# Altium schematic sheet Fasma_Drum_final.SchDoc
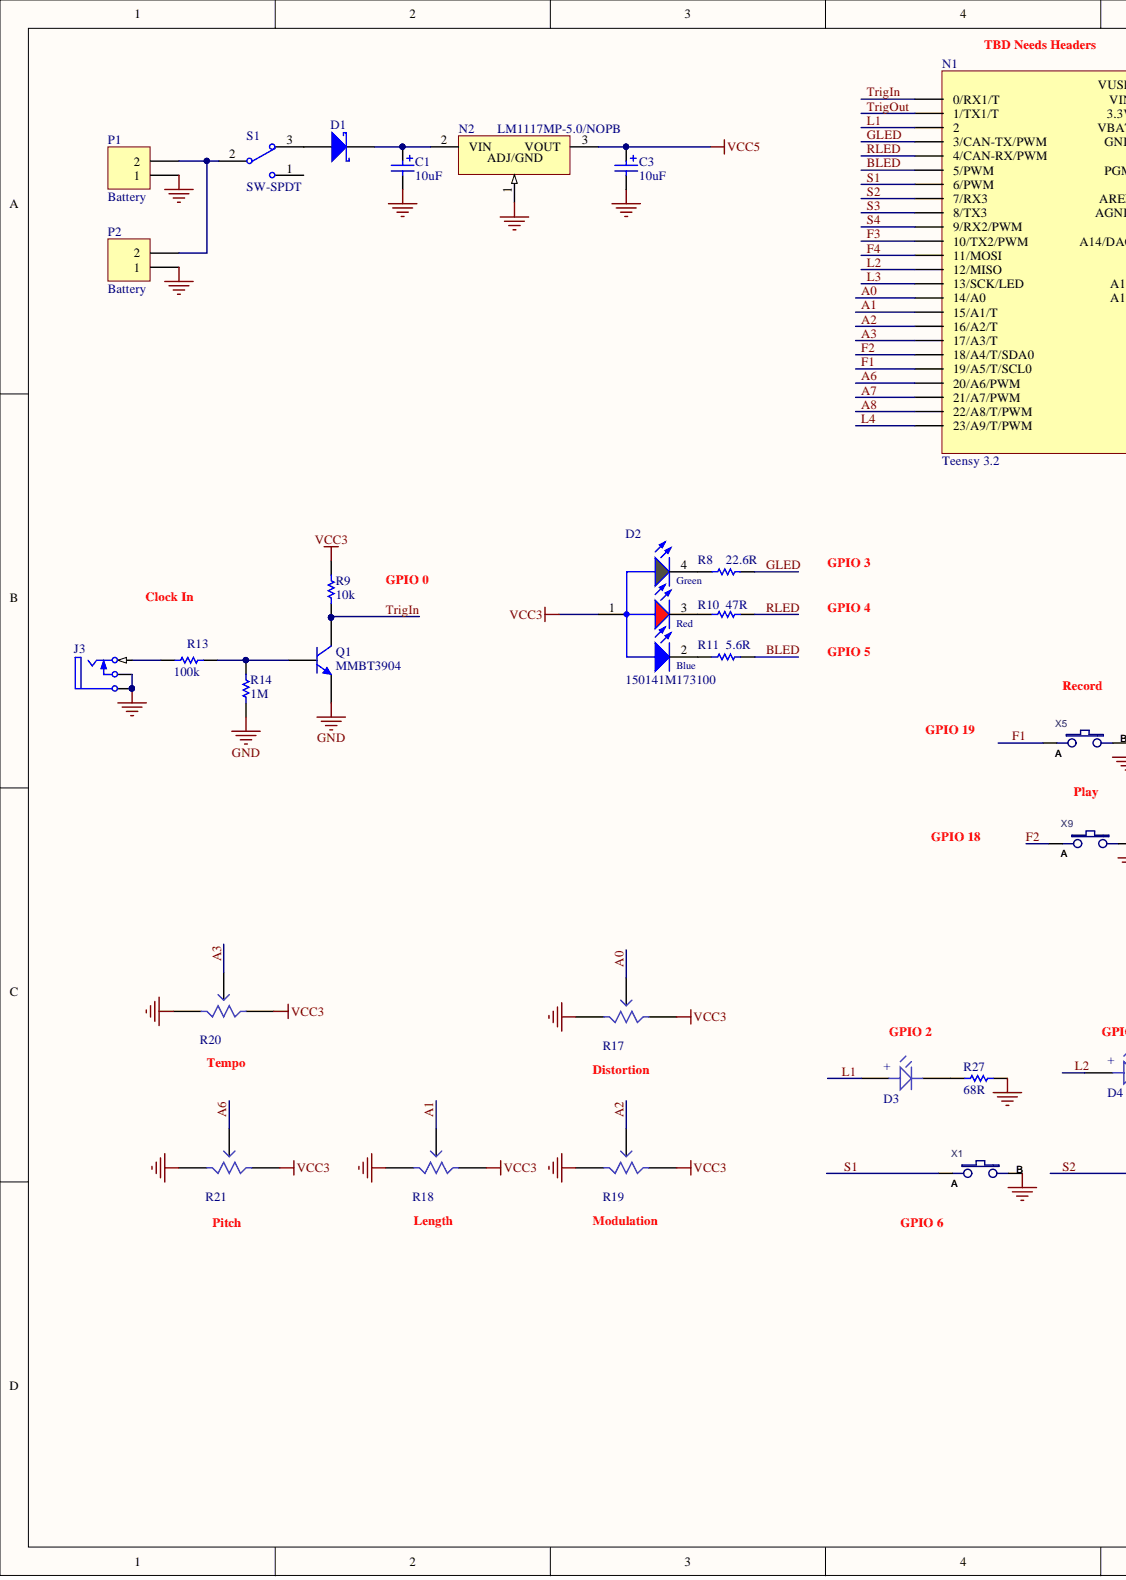
[diagram p0: Fasma_Drum_final.SchDoc, left]
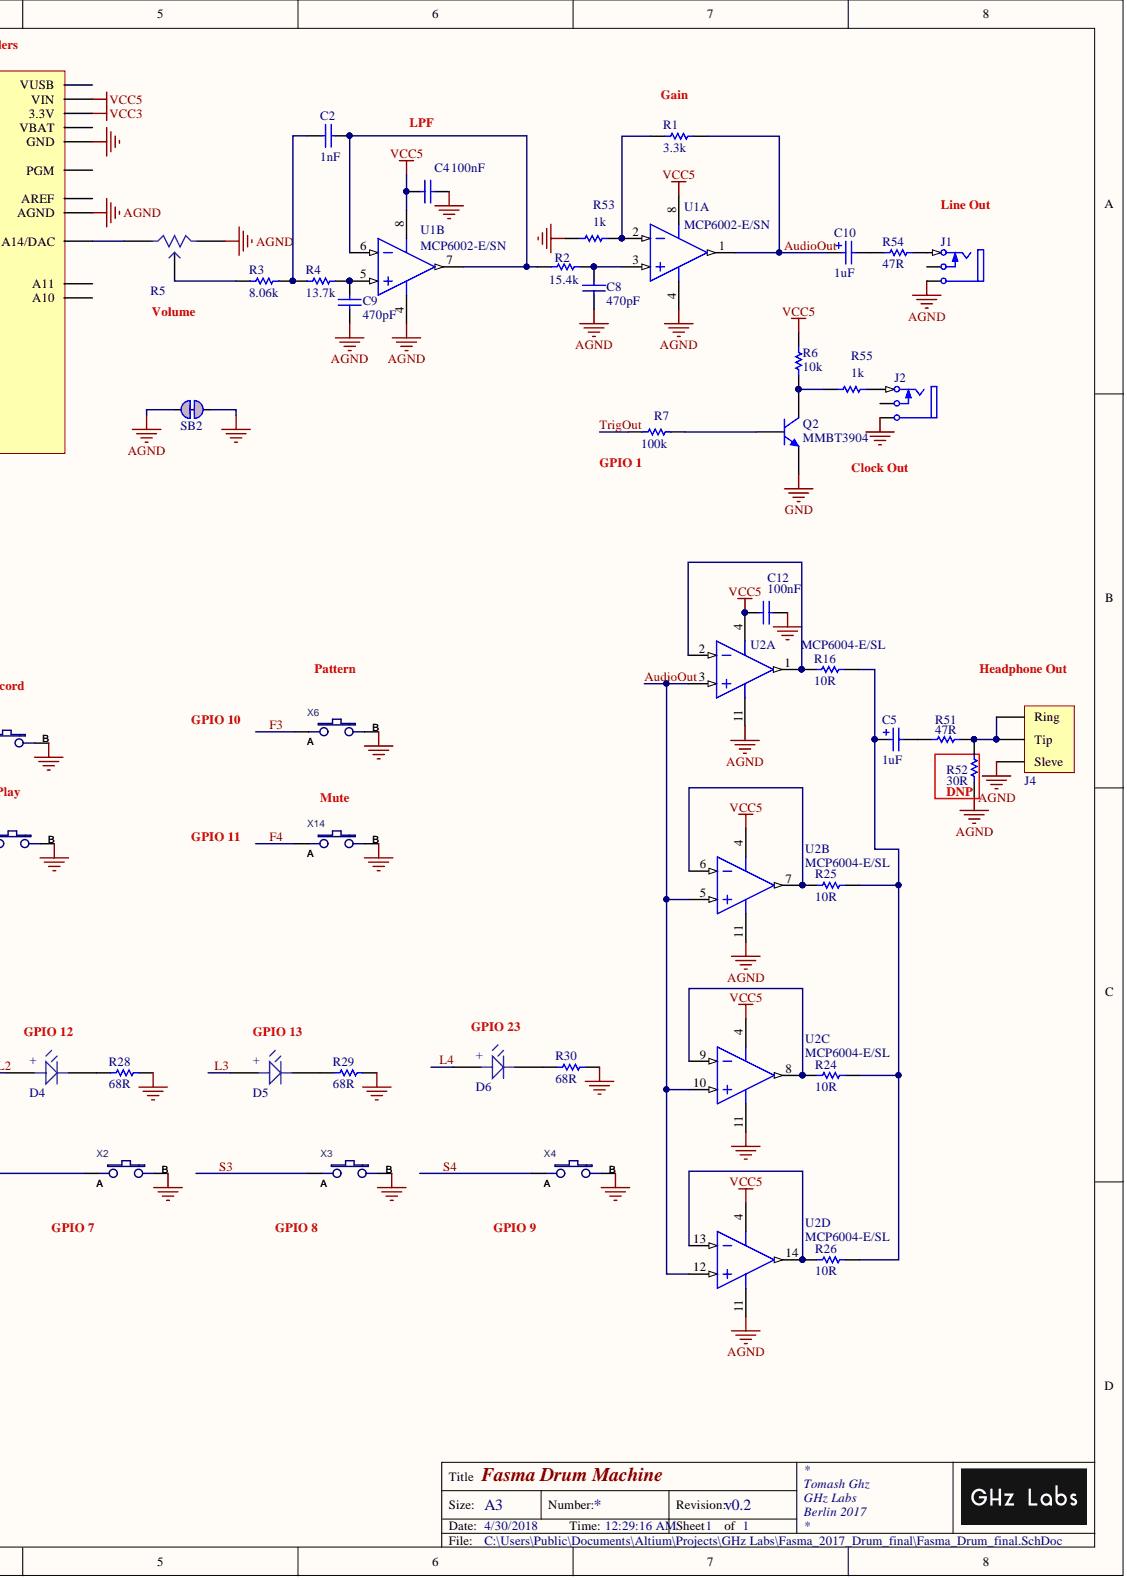
[diagram p1: Fasma_Drum_final.SchDoc, right]

## Parts
C1  10uF  [CAPA_0805 Pol]
C2  1nF  [CAPA_0805]
C3  10uF  [CAPA_0805 Pol]
C4  100nF  [CAPA_0805]
C5  1uF  [CAPA_0805 Pol]
C8  value 470pF  [CAPA_0805]  (hidden comment: 470pF 0805)
C9  value 470pF  [CAPA_0805]  (hidden comment: 470pF 0805)
C10  1uF  [CAPA_0805 Pol]
C12  100nF  [CAPA_0805]
D1  SD0805S020S1R0  [DIODE 0805]  (value not drawn)
D2  150141M173100  [WL-SFTW_3528]
D3  LEDsmd  [DIODE 0805]  (value not drawn)
D4  LEDsmd  [DIODE 0805]  (value not drawn)
D5  LEDsmd  [DIODE 0805]  (value not drawn)
D6  LEDsmd  [DIODE 0805]  (value not drawn)
J1  Phonejack2 TN  [Thonkiconn]  (value not drawn)
J2  Phonejack2 TN  [Thonkiconn]  (value not drawn)
J3  Phonejack2 TN  [Thonkiconn]  (value not drawn)
J4  Headhpone  [HeadphoneJack]  (value not drawn)
N1  Teensy 3.2  [TEENSY_3.0-3.2&LC_ALL_PINS]
N2  LM1117MP-5.0/NOPB  [SOT223]
P1  Battery  [9V Battery Holder]
P2  Battery  [HDR1X2]
Q1  MMBT3904  [SOT23-3]
Q2  MMBT3904  [SOT23-3]
R1  3.3k  [RES_0805]
R2  15.4k  [RES_0805]
R3  8.06k  [RES_0805]
R4  13.7k  [RES_0805]
R5  Potentiometer  [POT-ALPHA]  (value not drawn)
R6  10k  [RES_0805]
R7  100k  [RES_0805]
R8  22.6R  [RES_0805]
R9  10k  [RES_0805]
R10  47R  [RES_0805]
R11  5.6R  [RES_0805]
R13  100k  [RES_0805]
R14  1M  [RES_0805]
R16  10R  [RES_0805]
R17  Potentiometer  [POT-ALPHA]  (value not drawn)
R18  Potentiometer  [POT-ALPHA]  (value not drawn)
R19  Potentiometer  [POT-ALPHA]  (value not drawn)
R20  Potentiometer  [POT-ALPHA]  (value not drawn)
R21  Potentiometer  [POT-ALPHA]  (value not drawn)
R24  10R  [RES_0805]
R25  10R  [RES_0805]
R26  10R  [RES_0805]
R27  68R  [RES_0805]
R28  68R  [RES_0805]
R29  68R  [RES_0805]
R30  68R  [RES_0805]
R51  47R  [RES_0805]
R52  30R  [RES_0805]
R53  1k  [RES_0805]
R54  47R  [RES_0805]
R55  1k  [RES_0805]
S1  SW-SPDT  [SMD_Slide _Swith]
SB2  Solderbridge  [Solderbridge_Shorted]  (value not drawn)
U1  MCP6002-E/SN  [SOIC-SN8_N]  (parts A,B)
U2  MCP6004-E/SL  [SOIC-SL14_N]  (parts A,B,C,D)
X1  101-0124-EV  [Tactile_switch_12mm]  (value not drawn)
X2  101-0124-EV  [Tactile_switch_12mm]  (value not drawn)
X3  101-0124-EV  [Tactile_switch_12mm]  (value not drawn)
X4  101-0124-EV  [Tactile_switch_12mm]  (value not drawn)
X5  PTS645SM43SMTR 92 LFS  [Tactile_switch_6mm]  (value not drawn)
X6  PTS645SM43SMTR 92 LFS  [Tactile_switch_6mm]  (value not drawn)
X9  PTS645SM43SMTR 92 LFS  [Tactile_switch_6mm]  (value not drawn)
X14  PTS645SM43SMTR 92 LFS  [Tactile_switch_6mm]  (value not drawn)

## Nets
AGND: C4.2 C8.1 C9.1 C12.2 J1.5 J4.1 N1.AGND R5.1 R52.2 R53.1 SB2.1 U1.4 U2.11
GND: C1.2 C3.2 J2.5 J3.2 J3.5 N1.GND GND1(GND) N2.1 P1.1 P2.1 Q1.2 Q2.2 R14.1 R17.1 R18.1 R19.1 R20.1 R21.1 R27.1 R28.1 R29.1 R30.1 SB2.2 X1.2 X2.2 X3.2 X4.2 X5.2 X6.2 X9.2 X14.2
VCC3: D2.1 N1.3.3V 3.3V1(3.3V) R9.2 R17.3 R18.3 R19.3 R20.3 R21.3
VCC5: C3.1 C4.1 C12.1 N1.VIN N2.3 R6.2 U1.8 U2.4
A0: N1.14/A0 R17.2
A1: N1.15/A1(15/A1/T) R18.2
A2: N1.16/A2(16/A2/T) R19.2
A3: N1.17/A3(17/A3/T) R20.2
A6: N1.20/A6(20/A6/PWM) R21.2
A7: N1.21/A7(21/A7/PWM)
A8: N1.22/A8(22/A8/T/PWM)
AUDIOOUT: C10.1 R1.1 U1.1 U2.3 U2.5 U2.10 U2.12
BLED: N1.5(5/PWM) R11.2
F1: N1.19/A5(19/A5/T/SCL0) X5.1
F2: N1.18/A4(18/A4/T/SDA0) X9.1
F3: N1.10(10/TX2/PWM) X6.1
F4: N1.11(11/MOSI) X14.1
GLED: N1.3(3/CAN-TX/PWM) R8.2
L1: D3.1 N1.2
L2: D4.1 N1.12(12/MISO)
L3: D5.1 N1.13(13/SCK/LED)
L4: D6.1 N1.23/A9(23/A9/T/PWM)
RLED: N1.4(4/CAN-RX/PWM) R10.2
S1: N1.6(6/PWM) X1.1
S2: N1.7(7/RX3) X2.1
S3: N1.8(8/TX3) X3.1
S4: N1.9(9/RX2/PWM) X4.1
TRIGIN: N1.0(0/RX1/T) Q1.3 R9.1
TRIGOUT: N1.1(1/TX1/T) R7.1
NetC1_1: C1.1 D1.2 N2.2
NetC2_1: C2.1 R3.1 R4.2
NetC2_2: C2.2 R2.2 U1.6 U1.7
NetC5_1: C5.1 R16.1 R24.1 R25.1 R26.1
NetC5_2: C5.2 R51.2
NetC8_2: C8.2 R2.1 U1.3
NetC9_2: C9.2 R4.1 U1.5
NetC10_2: C10.2 R54.2
NetD1_1: D1.1 S1.3
NetD2_2: D2.2 R11.1
NetD2_3: D2.3 R10.1
NetD2_4: D2.4 R8.1
NetD3_2: D3.2 R27.2
NetD4_2: D4.2 R28.2
NetD5_2: D5.2 R29.2
NetD6_2: D6.2 R30.2
NetJ1_1: J1.1 R54.1
NetJ2_1: J2.1 R55.2
NetJ3_1: J3.1 R13.1
NetJ4_2: J4.2 J4.3 R51.1 R52.1
NetN1_RESET/DAC: N1.RESET/DAC(A14/DAC) R5.3
NetP1_2: P1.2 P2.2 S1.2
NetQ1_1: Q1.1 R13.2 R14.2
NetQ2_1: Q2.1 R7.2
NetQ2_3: Q2.3 R6.1 R55.1
NetR1_2: R1.2 R53.2 U1.2
NetR3_2: R3.2 R5.2
NetR16_2: R16.2 U2.1 U2.2
NetR24_2: R24.2 U2.8 U2.9
NetR25_2: R25.2 U2.6 U2.7
NetR26_2: R26.2 U2.13 U2.14

Not connected: J1 2; J2 2; N1 A10 A11 AREF PGM VBAT VUSB; S1 1

## Library data of the parts
C1 C3 = Cap Pol2: Polarized Capacitor; Manufacturer: AVX; PartNumber: 0805YD106KAT4A; Component Kind: Standard; Library Name: Miscellaneous Devices.IntLib; Mouser: http://www.mouser.de/ProductDetail/AVX/0805YD106KAT4A/?qs=sGAEpiMZZMs0AnBnWHyRQJFx1SN4r7R8m3hIY7XI0BM%3d; Signal Integrity: Cap Pol; Simulation: CAP; Value: 10uF
C2 = Capacitor: 1nF 0805; Manufacturer: AVX; PartNumber: 08053F102K4T2A; Component Kind: Standard; Library Name: myParts.SchLib; Mouser: http://www.mouser.de/ProductDetail/AVX/08053F102K4T2A/?qs=sGAEpiMZZMs0AnBnWHyRQLdZmHBztYFC%2f8NUDVqJmWhxmSPk3oXULQ%3d%3d; Signal Integrity: Cap; Simulation: CAP; Value: 1nF
C4 C12 = Capacitor: 100nF 0805; PartNumber: 885012207072; Component Kind: Standard; Library Name: myParts.SchLib; Mouser: http://www.mouser.de/ProductDetail/Wurth-Electronics/885012207072/?qs=sGAEpiMZZMs0AnBnWHyRQEGbLOF2VP1ibTWUyjubWr0tPlz%2f3fbAWQ%3d%3d; Signal Integrity: Cap; Simulation: CAP; Value: 100nF
C5 C10 = Cap Pol2: Polarized Capacitor; Manufacturer: Yageo; PartNumber: CC0805KKX7R7BB105; Component Kind: Standard; Library Name: Miscellaneous Devices.IntLib; Mouser: http://www.mouser.de/ProductDetail/Yageo/CC0805KKX7R7BB105/?qs=sGAEpiMZZMs0AnBnWHyRQMMzfhmH8IQXUrQ8mIpMCrg%3d; Signal Integrity: Cap Pol; Simulation: CAP; Value: 1uF
C8 C9 = Capacitor: =Value; Manufacturer: Wurth Electronics; PartNumber: 885012207006; Component Kind: Standard; Description: 470pF; Library Name: myParts.SchLib; Mouser: http://www.mouser.de/ProductDetail/Wurth-Electronics/885012207006/?qs=sGAEpiMZZMs0AnBnWHyRQEGbLOF2VP1iB0oy0tSgyY1K8MN0QxKEDw%3d%3d; Signal Integrity: Cap; Simulation: CAP
D1 = D Schottky: Schottky Diode; Alternative part: http://www.mouser.de/ProductDetail/AVX/SD1206T040S1R0/?qs=sGAEpiMZZMtQ8nqTKtFS%2fB%252bvZQIExTNLVUxpicOfnng%3d; Component Kind: Standard; Library Name: Miscellaneous Devices.IntLib; Mouser: http://www.mouser.de/ProductDetail/AVX/SD0805S020S1R0/?qs=%2fha2pyFadugLLnjfmIXK2NHSZyFxbwgz75APfQsPcvJ7VW78TEtwhg%3d%3d; PackageReference: 0805; PartNumber: SD0805S020S1R0; Signal Integrity: D Schottky; Simulation: SKYDIODE
D2 = 150141M173100: WL-SFTW SMD Full-color TOP LED Waterclear; Manufacturer: Wurth Elektronik; Emitting Color: Red & Green & Blue; Viewing Angle: 120°; Component Kind: Standard; ComponentLink1Description: Manufacturer; ComponentLink1URL: http://www.we-online.de; ComponentLink2Description: Datasheet; ComponentLink2URL: http://katalog.we-online.de/led/datasheet/150141M173100.pdf; Library Name: ALTIUM-WL-SFTW-rev17a.IntLib; Mouser: http://www.mouser.de/search/ProductDetail.aspx?R=0virtualkey0virtualkey150141M173100; PartNumber: 150141M173100; Published Date: Jan 2017; Size: 3528
D3-D6 = LEDsmd: Manufacturer: Kingbright; PartNumber: APT2012YC; Component Kind: Standard; Library Name: myParts.SchLib; Mouser: http://www.mouser.de/ProductDetail/Kingbright/APT2012YC/?qs=sGAEpiMZZMseGfSY3csMkeytxqHAv00AKvOaAyVdWVo%3d
J1-J3 = Phonejack2 TN: Jack Socket, 1/4" [6.5mm], Thru-Hole, Vertical, 2-Conductor with Tip closed; Manufacturer: Amphenol Audio; Part: ACJM-MV35-2S; Component Kind: Standard; Datasheet: http://www.amphenolaudio.com/wp-content/uploads/2015/07/55010544-001-rev-2.pdf; Library Name: myParts.SchLib; Mouser: http://www.mouser.de/ProductDetail/Amphenol/ACJM-MV35-2S/?qs=%2fha2pyFaduh8uNgKuHZ7oEtHXwcSE0WAH4R1pjDPuhAHZsUXTeJRNg%3d%3d; Signal Integrity: JACK Socket
J4 = HeadphoneJack: Headphone Jack 3.5mm; Manufacturer: CUI; PartNumber: SJ1-3523N; Component Kind: Standard; Datasheet: http://www.mouser.com/ds/2/670/sj1-352xn-series-535548.pdf; Library Name: myParts.SchLib; Mouser: http://www.mouser.de/Search/ProductDetail.aspx?R=SJ1-3523Nvirtualkey51780000virtualkey490-SJ1-3523N
N1 = TEENSY_3.1-3.2_ALL_PINS: Manufacturer: SparkFun; PartNumber: DEV-13736; Component Kind: Standard; Library Name: Teensy_3_and_LC_Series_Boards_v1.SCHLIB; Mouser: http://www.mouser.de/ProductDetail/SparkFun/DEV-13736/?qs=sGAEpiMZZMuWWq7rhECaKVjnUlbUDjFgBj37EAXueZA%3d
N2 = LM1117: LDO Voltage Regulator; Component Kind: Standard; Datasheet: http://www.ti.com/lit/ds/symlink/lm1117.pdf; Library Name: myParts.SchLib; Mouser: http://www.mouser.de/ProductDetail/Texas-Instruments/LM1117MP-50-NOPB/?qs=sGAEpiMZZMsGz1a6aV8DcCERHZHPu4lvRf1QWbpzAts%3d; PartNumber: LM1117MP-5.0/NOPB
P1 P2 = Header 2: 9V  Battery Holder; Manufacturer: Eagle Plastic Devices; PartNumber: 12BH611-GR; Component Kind: Standard; Library Name: Miscellaneous Connectors.IntLib; Mouser: http://www.mouser.de/ProductDetail/Eagle-Plastic-Devices/12BH611-GR/?qs=%2fha2pyFadujY7%252b%2fdRKk4Kd3gO05Q9pve7cdXlL6UMjg%3d; MouserPart: 12BH611-GR; Signal Integrity: Connector
  P1: Alternative: http://www.mouser.de/ProductDetail/Keystone-Electronics/1294/?qs=sGAEpiMZZMsb53hqUvtGaal96fsGiPnZeBRTs87TntQ%3d
Q1 Q2 = MMBT3904: NPN General Purpose Transistor; Manufacturer: ON Semiconductor; PartNumber: MMBT3904LT1G; Component Kind: Standard; Datasheet: http://www.mouser.com/ds/2/308/MMBT3904LT1-D-113169.pdf; Library Name: myParts.SchLib; Mouser: http://www.mouser.de/ProductDetail/ON-Semiconductor/MMBT3904LT1G/?qs=sGAEpiMZZMshyDBzk1%2fWi%2fPUgtclNldlheHc%252bMVjFj0%3d; Signal Integrity: 2N3904; Simulation: 2N3904
R1 = Resistor: 3.3k 0805; Manufacturer: Susumu; PartNumber: RR1220P-332-D; Component Kind: Standard; Library Name: myParts.SchLib; Mouser: http://www.mouser.de/ProductDetail/Susumu/RR1220P-332-D/?qs=sGAEpiMZZMu61qfTUdNhG%2fDhcyQpRg8MWbTJdgzdVP0%3d; Signal Integrity: Res; Simulation: RES; Supplier: Mouser; Supplier Part Number 1: 667-ERJ-6ENF5231V; Value: 3.3k
R2 = Resistor: 15.4k 0805; Manufacturer: Panasonic; PartNumber: ERJ-6ENF1542V; Component Kind: Standard; Library Name: myParts.SchLib; Mouser: http://www.mouser.de/ProductDetail/Panasonic/ERJ-6ENF1542V/?qs=sGAEpiMZZMvdGkrng054t8AJgcdMkx7xA5NjIfoq9oU%3d; Signal Integrity: Res; Simulation: RES; Value: 15.4k
R3 = Resistor: Manufacturer: Vishay; PartNumber: CRCW08058K06FKEA; Component Kind: Standard; Library Name: myParts.SchLib; Mouser: http://www.mouser.de/ProductDetail/Vishay/CRCW08058K06FKEA/?qs=sGAEpiMZZMvdGkrng054txEw7b1YnvGukLyptRifrNE%3d; Signal Integrity: Res; Simulation: RES; Value: 8.06k
R4 = Resistor: 13.7k 0805; Manufacturer: Susumu; PartNumber: RR1220P-1372-D-M; Component Kind: Standard; Library Name: myParts.SchLib; Mouser: http://www.mouser.de/ProductDetail/Susumu/RR1220P-1372-D-M/?qs=sGAEpiMZZMu61qfTUdNhG%2fDhcyQpRg8MF4eIy3IoAgc%3d; Signal Integrity: Res; Simulation: RES; Value: 13.7k
R5 R17-R19 R21 = Potentiometer: PartNumber: PTV09A-4020U-B104; Component Kind: Standard; Library Name: myParts.SchLib; Mouser: http://www.mouser.de/Search/ProductDetail.aspx?R=PTV09A-4020U-B104virtualkey65210000virtualkey652-PTV09A4020U-B104
R6 R9 = Resistor: 10k 0805; Manufacturer: Susumu; PartNumber: RR1220P-103-D; Component Kind: Standard; Library Name: myParts.SchLib; Mouser: http://www.mouser.de/ProductDetail/Susumu/RR1220P-103-D/?qs=sGAEpiMZZMu61qfTUdNhG%2fDhcyQpRg8MdkVTtHR2gV4%3d; Signal Integrity: Res; Simulation: RES; Value: 10k
R7 R13 = Resistor: 100k 0805; Manufacturer: Susumu; PartNumber: RR1220P-104-D; Component Kind: Standard; Library Name: myParts.SchLib; Mouser: http://www.mouser.de/ProductDetail/Susumu/RR1220P-104-D/?qs=sGAEpiMZZMu61qfTUdNhG%2fDhcyQpRg8MUHr%252b%2fl2FgBo%3d; Signal Integrity: Res; Simulation: RES; Value: 100k
R8 = Resistor: 22.6R 0805; Manufacturer: Susumu; PartNumber: RR1220Q-22R6-D-M; Component Kind: Standard; Library Name: myParts.SchLib; Mouser: http://www.mouser.de/ProductDetail/Susumu/RR1220Q-22R6-D-M/?qs=sGAEpiMZZMu61qfTUdNhG%2fDhcyQpRg8MqrlGYoXdDfg%3d; Signal Integrity: Res; Simulation: RES; Value: 22.6R
R10 R51 R54 = Resistor: 47R 0805; Manufacturer: Susumu; PartNumber: RR1220Q-470-D; Component Kind: Standard; Library Name: myParts.SchLib; Mouser: http://www.mouser.de/ProductDetail/Susumu/RR1220Q-470-D/?qs=sGAEpiMZZMu61qfTUdNhG%2fDhcyQpRg8M5%2fUISpqjOQ8%3d; Signal Integrity: Res; Simulation: RES; Value: 47R
R11 = Resistor: 5.6R 0805; Manufacturer: Yageo; PartNumber: RC0805FR-075R6L; Component Kind: Standard; Library Name: myParts.SchLib; Mouser: http://www.mouser.de/ProductDetail/Yageo/RC0805FR-075R6L/?qs=sGAEpiMZZMtG0KNrPCHnjTg0x5DoQJ5PbU53mb%2fk%252beo%3d; Signal Integrity: Res; Simulation: RES; Value: 5.6R
R14 = Resistor: 1M 0805; Manufacturer: Susumu; PartNumber: RR1220P-105-D; Component Kind: Standard; Library Name: myParts.SchLib; Mouser: http://www.mouser.de/ProductDetail/Susumu/RR1220P-105-D/?qs=sGAEpiMZZMu61qfTUdNhG%2fDhcyQpRg8MxiYDTLm9lx4%3d; Signal Integrity: Res; Simulation: RES; Value: 1M
R16 R24-R26 = Resistor: 10R 0805; Manufacturer: Susumu; PartNumber: RR1220Q-100-D; Component Kind: Standard; Library Name: myParts.SchLib; Mouser: http://www.mouser.de/ProductDetail/Susumu/RR1220Q-100-D/?qs=sGAEpiMZZMu61qfTUdNhG%2fDhcyQpRg8MM8QFZr9IO10%3d; Signal Integrity: Res; Simulation: RES; Value: 10R
R20 = Potentiometer: Manufacturer: Bourns; PartNumber: PTV09A-4020U-B104; Component Kind: Standard; Library Name: myParts.SchLib; Mouser: http://www.mouser.de/Search/ProductDetail.aspx?R=PTV09A-4020U-B104virtualkey65210000virtualkey652-PTV09A4020U-B104
R27-R30 = Resistor: 68R 0805; Manufacturer: Susumu; PartNumber: RR1220Q-680-D; Component Kind: Standard; Library Name: myParts.SchLib; Mouser: http://www.mouser.de/ProductDetail/Susumu/RR1220Q-680-D/?qs=sGAEpiMZZMtG0KNrPCHnjQwywlrZK4avnk7B9BRjmJg%3d; Signal Integrity: Res; Simulation: RES; Value: 68R
R52 = Resistor: 30R 0805; Manufacturer: Susumu; PartNumber: RR1220Q-300-D; Component Kind: Standard; Library Name: myParts.SchLib; Mouser: http://www.mouser.de/ProductDetail/Susumu/RR1220Q-300-D/?qs=sGAEpiMZZMu61qfTUdNhG%2fDhcyQpRg8Mq3Oac45CdT4%3d; Signal Integrity: Res; Simulation: RES; Value: 30R
R53 R55 = Resistor: 1k 0805; Manufacturer: Susumu; PartNumber: RR1220P-102-D; Component Kind: Standard; Library Name: myParts.SchLib; Mouser: http://www.mouser.de/Search/ProductDetail.aspx?R=RR1220P-102-Dvirtualkey67280000virtualkey754-RR1220P-102D; Signal Integrity: Res; Simulation: RES; Value: 1k
S1 = SW-SPDT: SPDT Subminiature Toggle Switch, Right Angle Mounting, Vertical Actuation; Manufacturer: C&K Components; PartNumber: JS102011SAQN; Component Kind: Standard; Library Name: Miscellaneous Devices.IntLib; Mouser: http://www.mouser.de/ProductDetail/CK-Components/JS102011SAQN/?qs=%2fha2pyFaduiV2nqaS%252bU8Q9wtp%2fpUP0ZhxQ7YjhJWd98Vv%2fajANoXBg%3d%3d
SB2 = SOLDERBRIDGE_SHORTED: Shorted Solderbridge; Part Number: Solderbridge Shorted; Artikkelnr Noca: N.A.; Artikkelnr Simpro: N.A.; Component Kind: Net Tie; Footprint Doc: Solderbridge_Shorted; LatestRevisionDate: 2012-08-07; Library Name: myParts.SchLib; Pin Count: 2; Published: 2012-08-07; Value: Solderbridge; Publisher: Nordic Semiconductor
U1 = MCP6002-E/SN: 1 MHz, Low-Power Operational Amplifier, 8-Pin SOIC, Extended Temperature; Manufacturer: Microchip Technology; Code_IPC: SOIC127P600-8; Code_JEDEC: MS-012; Component Kind: Standard; ComponentLink1Description: Datasheet; ComponentLink1URL: http://ww1.microchip.com/downloads/en/DeviceDoc/21733j.pdf; ComponentLink2Description: Packaging Specification; ComponentLink2URL: http://www.microchip.com/stellent/groups/techpub_sg/documents/packagingspec/en012702.pdf; ComponentLink3Description: Microchip.com Home; ComponentLink3URL: http://www.microchip.com/; DatasheetVersion: revJ, Nov-2009; LatestRevisionDate: 02-Dec-2009; LatestRevisionNote: Updated to datasheet revision J, Nov-2009; Library Name: Microchip Linear Devices.IntLib; Mouser: http://www.mouser.de/ProductDetail/Microchip-Technology/MCP6002-E-SN/?qs=sGAEpiMZZMtCHixnSjNA6Gd4d3iEGJDL5TlYqP8oblM%3d; PackageDescription: 8-Lead Plastic Small Outline (SN) - Narrow, 3.90mm Body [SOIC]; PackageReference: SOIC-SN8; PackageVersion: revBB, Aug-2009; PartNumber: MCP6002-E/SN; Published: 29-Jan-2009; Simulation: MCP6001; Supplier 1: Digi-Key; Supplier 2: Farnell; Supplier Part Number 1: MCP6002-E/SN-ND; Supplier Part Number 2: 1332118; Publisher: Altium Limited
U2 = MCP6004-E/SL: 1 MHz, Low-Power Operational Amplifier, 14-Pin SOIC, Extended Temperature; Manufacturer: Microchip Technology; PartNumber: MCP6004T-E/SL; Code_IPC: SOIC127P600-14; Code_JEDEC: MS-012-AB; Component Kind: Standard; ComponentLink1Description: Datasheet; ComponentLink1URL: http://ww1.microchip.com/downloads/en/DeviceDoc/21733j.pdf; ComponentLink2Description: Packaging Specification; ComponentLink2URL: http://www.microchip.com/stellent/groups/techpub_sg/documents/packagingspec/en012702.pdf; ComponentLink3Description: Microchip.com Home; ComponentLink3URL: http://www.microchip.com/; DatasheetVersion: revJ, Nov-2009; LatestRevisionDate: 02-Dec-2009; LatestRevisionNote: Updated to datasheet revision J, Nov-2009; Library Name: Microchip Linear Devices.IntLib; Mouser: http://www.mouser.de/ProductDetail/Microchip-Technology/MCP6004T-E-SL/?qs=sGAEpiMZZMtCHixnSjNA6N5WMYiF%252b1miedbE5%2f40CfM%3d; PackageDescription: 14-Lead Plastic Small Outline (SL) - Narrow, 3.90mm Body [SOIC]; PackageReference: SOIC-SL14; PackageVersion: revBB, Aug-2009; Published: 29-Jan-2009; Simulation: MCP6001; Supplier 1: Digi-Key; Supplier 2: Farnell; Supplier Part Number 1: MCP6004-E/SL-ND; Supplier Part Number 2: 1332122; Publisher: Altium Limited
X1-X4 = SWITCH/Push Button-EVPAWBA2A: Push Button SMD EVPAWBA2A; Manufacturer: Mountain Switch; Component Kind: Standard; Mouser: http://www.mouser.de/ProductDetail/Mountain-Switch/101-0124-EV/?qs=%2fha2pyFadugyCNAhIStjRh4o9JIzyQM7dCKObtyroRU%3d; PartNumber: 101-0124-EV; Library Name: Basslet.SchLib
X5 X6 X9 X14 = SWITCH/Push Button-EVPAWBA2A: Push Button SMD EVPAWBA2A; Manufacturer: C&K Components; Component Kind: Standard; Mouser: http://www.mouser.de/ProductDetail/CK-Components/PTS645SM43SMTR-92-LFS/?qs=%2fha2pyFadug0Azfe8RMwGSYmKCJckqYIWMcwD6l1nrwDsL%252b1QhwZ%2fZ403JZA6uFq; PartNumber: PTS645SM43SMTR 92 LFS; Library Name: Basslet.SchLib
